# Altium schematic sheet EFE.SchDoc(EFE)
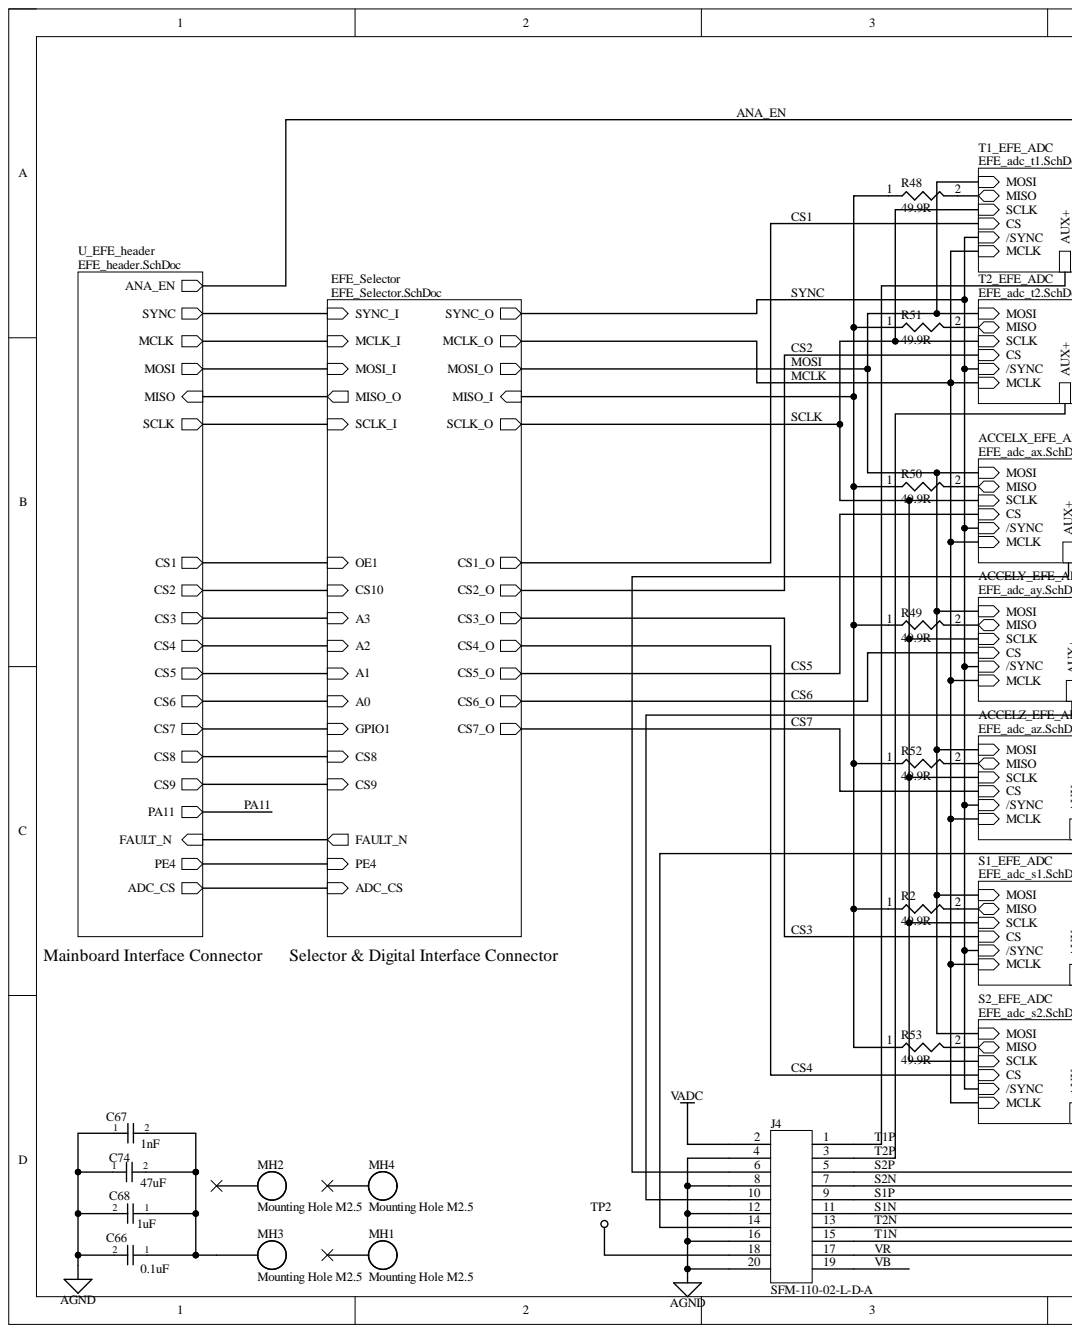
[diagram p0: EFE.SchDoc, left]
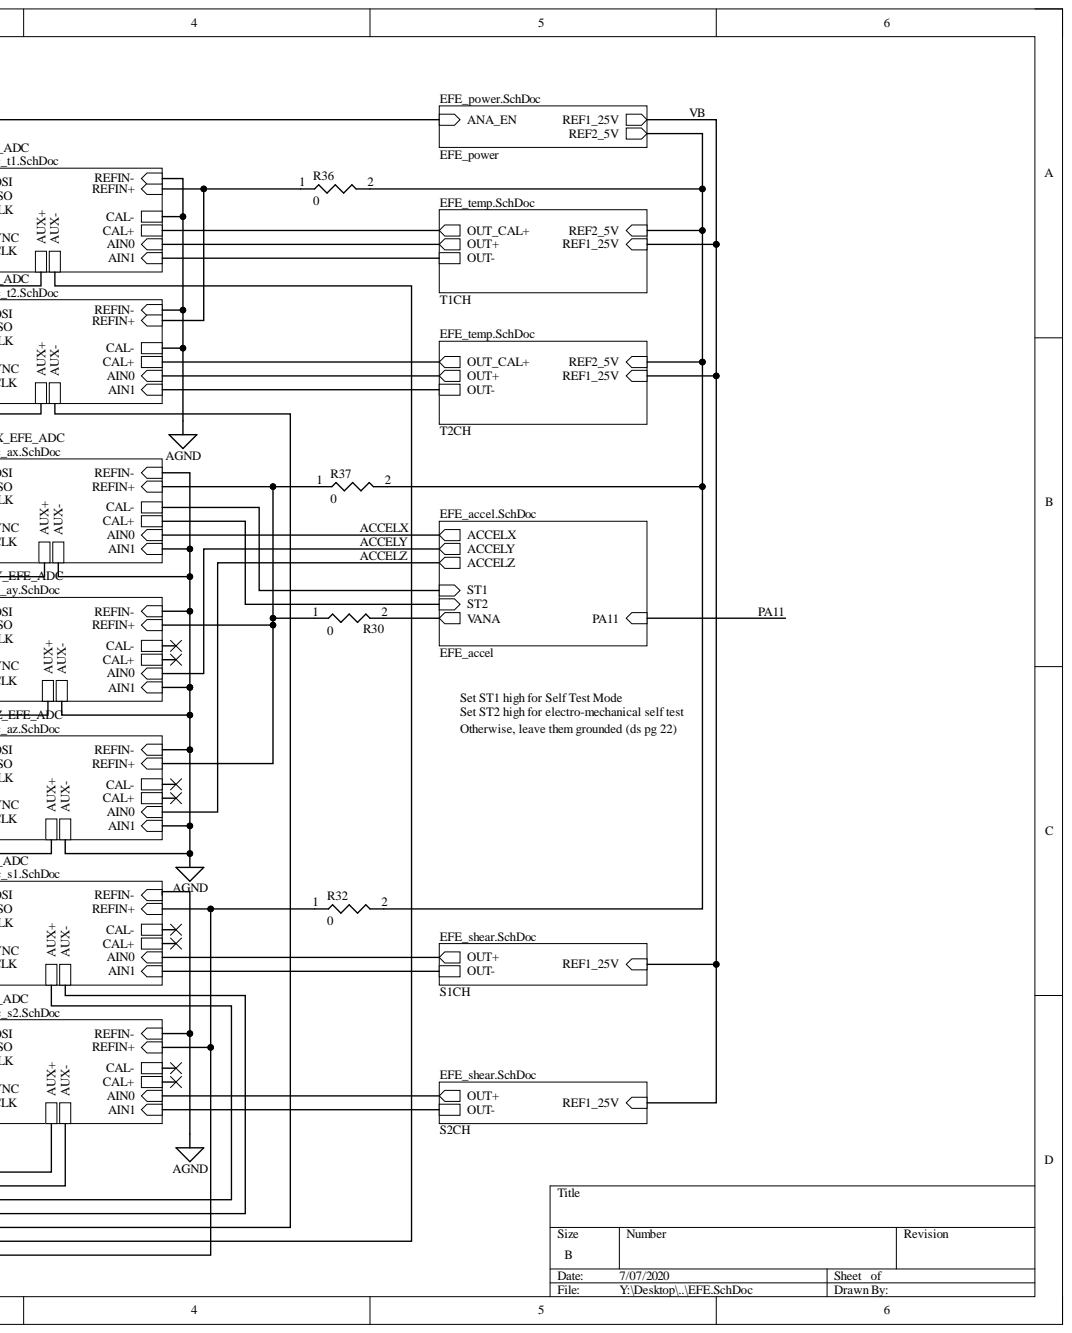
[diagram p1: EFE.SchDoc, right]

## Parts
C66  value 0.1uF  [2012, Concave]  (hidden comment: CC0805KRX7R9BB104)
C67  Capacitance: 1nF  [2012, Concave]  (hidden comment: C0805C102K5RACTU)
C68  Capacitance: 1uF  [2012, Concave]  (hidden comment: CL21B105KBFNNNG)
C74  Capacitance: 47uF  [1210 - Non-Polar]  (hidden comment: TMK325ABJ476MM-P)
J4  SFM-110-02-L-D-A  [SFM-110-02-L-D-A]
MH1  Mounting Hole M2.5  [MOUNTING_HOLE M2.5]
MH2  Mounting Hole M2.5  [MOUNTING_HOLE M2.5]
MH3  Mounting Hole M2.5  [MOUNTING_HOLE M2.5]
MH4  Mounting Hole M2.5  [MOUNTING_HOLE M2.5]
R2  Resistance: 49.9R  [0805]  (hidden comment: ERA-6AEB49R9V)
R30  value 0  [0805]  (hidden comment: RC0805JR-070RL)
R32  value 0  [0805]  (hidden comment: RC0805JR-070RL)
R36  value 0  [0805]  (hidden comment: RC0805JR-070RL)
R37  value 0  [0805]  (hidden comment: RC0805JR-070RL)
R48  Resistance: 49.9R  [0805]  (hidden comment: ERA-6AEB49R9V)
R49  Resistance: 49.9R  [0805]  (hidden comment: ERA-6AEB49R9V)
R50  Resistance: 49.9R  [0805]  (hidden comment: ERA-6AEB49R9V)
R51  Resistance: 49.9R  [0805]  (hidden comment: ERA-6AEB49R9V)
R52  Resistance: 49.9R  [0805]  (hidden comment: ERA-6AEB49R9V)
R53  Resistance: 49.9R  [0805]  (hidden comment: ERA-6AEB49R9V)
TP2  Test-Pad 0805  [TestPad - 0805]  (value not drawn)

## Nets
AGND: C66.2 C67.1 C68.2 C74.1 J4.4 J4.8 J4.12 J4.16 J4.20
VADC: J4.2
S1N: J4.11
S1P: J4.9
S2N: J4.7
S2P: J4.5
T1N: J4.15
T1P: J4.1
T2N: J4.13
T2P: J4.3
VB: J4.19
VR: J4.17 R32.1
NetC66_1: C66.1 C67.2 C68.1 C74.2 MH3.1
NetJ4_6: J4.6
NetJ4_10: J4.10
NetJ4_14: J4.14
NetJ4_18: J4.18 TP2.1
NetMH1_1: MH1.1
NetMH2_1: MH2.1
NetMH4_1: MH4.1
NetR2_1: R2.1 R48.1 R49.1 R50.1 R51.1 R52.1 R53.1
NetR2_2: R2.2
NetR30_1: R30.1 R37.1
NetR30_2: R30.2
NetR32_2: R32.2 R36.2 R37.2
NetR36_1: R36.1
NetR48_2: R48.2
NetR49_2: R49.2
NetR50_2: R50.2
NetR51_2: R51.2
NetR52_2: R52.2
NetR53_2: R53.2
ACCELX:
ACCELY:
ACCELZ:
ANA_EN:
CS1:
CS2:
CS3:
CS4:
CS5:
CS6:
CS7:
MCLK:
MOSI:
N00001:
N00002:
N00003:
N00004:
N00005:
N00006:
N00007:
N00008:
N00009:
N00010:
N00011:
N00012:
N00013:
N00014:
N00015:
N00016:
N00017:
N00018:
N00019:
N00020:
N00021:
N00022:
N00023:
N00024:
N00025:
N00026:
N00027:
N00028:
N00029:
N00030:
N00031:
N00032:
N00033:
N00034:
N00035:
N00036:
N00037:
PA11:
SCLK:
SYNC:

## Library data of the parts
C66 = CC0805KRX7R9BB104: Capacitor - Ceramic, 0.1uF, 50V 0805; Manufacturer: Yageo; Capacitance: 100nF; Dielectric: X7R; Insulation Resistance: 100GR; Tolerance: 10%; Case Code (Imperial): 0805; Case Code (Metric): 2012; Case/Package: 0805; Component Kind: Standard; ComponentLink1Description: http://www.yageo.com/documents/recent/UPY-GPHC_X7R_6.3V-to-50V_8.pdf; ComponentLink1URL: http://www.yageo.com/documents/recent/UPY-GPHC_X7R_6.3V-to-50V_8.pdf; ComponentLink2Description: http://www.farnell.com/datasheets/1489800.pdf; ComponentLink2URL: http://www.farnell.com/datasheets/1489800.pdf; ComponentLink3Description: http://www.yageo.com/documents/recent/UPY-GPHC_X7R_6.3V-to-50V_18.pdf; ComponentLink3URL: http://www.yageo.com/documents/recent/UPY-GPHC_X7R_6.3V-to-50V_18.pdf; ComponentLink4Description: http://www.farnell.com/datasheets/1734573.pdf; ComponentLink4URL: http://www.farnell.com/datasheets/1734573.pdf; ComponentLink5Description: http://www.produktinfo.conrad.com/datenblaetter/425000-449999/445210-da-01-en-KERKO_CHIP_0805_X7R_0_1uF_10Proz_50VG.pdf; ComponentLink5URL: http://www.produktinfo.conrad.com/datenblaetter/425000-449999/445210-da-01-en-KERKO_CHIP_0805_X7R_0_1uF_10Proz_50VG.pdf; Depth: 1.25mm; Halogen Free: Halogen Free; Height: 0.85mm; Lead Free: Lead Free; Length: 2mm; Manufacturer Part Number: CC0805KRX7R9BB104; Material: Ceramic; Max Operating Temperature: 125°C; Min Operating Temperature: -55°C; Mount: Surface Mount; Number of Pins: 2; Package Quantity: 1; Packaging: Tape and Reel; Pricing: 1 = 0.16000, 10 = 0.11300, 100 = 0.05290, 500 = 0.03712, 1000 = 0.03073 (USD); Radiation Hardening: No; REACH SVHC: No SVHC; RoHS: Yes; Stock: 524292; Supplier 1: Digi-Key; Supplier Part Number 1: 311-1140-1-ND; Termination: SMD/SMT; Thickness: 0.033inch; Voltage: 50V; Voltage Rating: 50V; Width: 0.049inch; Library Name: Epsilometer.SCHLIB
C67 = C0805C102K5RACTU: Capacitor - Ceramic, 1000pF, 50V, 0805; Manufacturer: TDK-Lambda; Dielectric: X7R; Tolerance: 10%; Case/Package: 0805; Component Kind: Standard; ComponentLink1Description: http://www.onlinecomponents.com/productFiles/mf-KEM/kem_c1002_x7r_smd.pdf; ComponentLink1URL: http://www.onlinecomponents.com/productFiles/mf-KEM/kem_c1002_x7r_smd.pdf; Dielectric Material: Ceramic; Height: 780um; Length: 2mm; Manufacturer Part Number: C0805C102K5RACTU; Number of Pins: 2; Packaging: Cut Tape; Pricing: 1 = 0.18000, 10 = 0.13000, 100 = 0.06130, 500 = 0.04296, 1000 = 0.03557 (USD); REACH SVHC: No SVHC; Stock: 1159370; Supplier 1: Digi-Key; Supplier Part Number 1: 399-1147-1-ND; Voltage Rating (DC): 50V; Width: 1.25mm
C68 = CL21B105KBFNNNG: Capacitor - Ceramic, 1uF, 50V, 0805; Manufacturer: Samsung; Dielectric: X7R; Tolerance: 10%; Case Code (Imperial): 0805; Case Code (Metric): 2012; Case/Package: 0805; Component Kind: Standard; ComponentLink1Description: http://www1.futureelectronics.com/doc/SAMSUNG%20PASSIVE/CL05B104KQ5NNNC.pdf; ComponentLink1URL: http://www1.futureelectronics.com/doc/SAMSUNG%20PASSIVE/CL05B104KQ5NNNC.pdf; ComponentLink2Description: https://www.distrelec.biz/Web/Downloads/_e/ng/MLCC_CatalogDownload2013_ENG.pdf?mime=application%2Fpdf; ComponentLink2URL: https://www.distrelec.biz/Web/Downloads/_e/ng/MLCC_CatalogDownload2013_ENG.pdf?mime=application%2Fpdf; ComponentLink3Description: http://www.samsungsem.com/kr/support/product-search/mlcc/CL21B105KBFNNNG.jsp; ComponentLink3URL: http://www.samsungsem.com/kr/support/product-search/mlcc/CL21B105KBFNNNG.jsp; ComponentLink4Description: https://produktinfo.conrad.com/datenblaetter/450000-474999/455898-da-01-en-VS_KONDENSATOR_0805.pdf; ComponentLink4URL: https://produktinfo.conrad.com/datenblaetter/450000-474999/455898-da-01-en-VS_KONDENSATOR_0805.pdf; Contact Plating: Tin; Depth: 1.25mm; Length: 2mm; Manufacturer Part Number: CL21B105KBFNNNG; Material: Ceramic; Max Operating Temperature: 125°C; Min Operating Temperature: -55°C; Mount: Surface Mount; Package Quantity: 3000; Packaging: Tape and Reel; Pricing: 1 = 0.14000, 10 = 0.10000, 100 = 0.04470, 500 = 0.03194, 1000 = 0.02509 (USD); RoHS: Yes; Stock: 556410; Supplier 1: Digi-Key; Supplier Part Number 1: 1276-6470-1-ND; Termination: Wraparound; Thickness: 0.053inch; Voltage: 50V; Voltage Rating: 50V; Width: 0.049inch; Library Name: Epsilometer.SCHLIB
C74 = TMK325ABJ476MM-P: Capacitor - Ceramic, 47uF, 25V, 1210; Manufacturer: Taiyo Yuden; Dielectric: X5R; Tolerance: 20%; Case/Package: Ceramic; Component Kind: Standard; ComponentLink1Description: http://ds.yuden.co.jp/TYCOMPAS/ut/detail.do?productNo=TMK325ABJ476MM-P&fileName=TMK325ABJ476MM-P_SS&mode=specSheetDownload&src-supplier=Digi-Key; ComponentLink1URL: http://ds.yuden.co.jp/TYCOMPAS/ut/detail.do?productNo=TMK325ABJ476MM-P&fileName=TMK325ABJ476MM-P_SS&mode=specSheetDownload&src-supplier=Digi-Key; ComponentLink2Description: http://www.yuden.co.jp/productdata/catalog/en/mlcc_all_e.pdf?src-supplier=Avnet+Asia; ComponentLink2URL: http://www.yuden.co.jp/productdata/catalog/en/mlcc_all_e.pdf?src-supplier=Avnet+Asia; ComponentLink3Description: https://ds.yuden.co.jp/TYCOMPAS/ut/detail?pn=TMK325ABJ476MM-P &amp;u=M&src-supplier=Digi-Key; ComponentLink3URL: https://ds.yuden.co.jp/TYCOMPAS/ut/detail?pn=TMK325ABJ476MM-P &amp;u=M&src-supplier=Digi-Key; Contact Plating: Tin; Lead Free: Lead Free; Length: 3.2mm; Manufacturer Part Number: TMK325ABJ476MM-P; Max Operating Temperature: 85°C; Min Operating Temperature: -55°C; Mount: Surface Mount; Packaging: Tape and Reel; Pricing: 1=1.35, 10=1.066, 100=0.7998, 500=0.6043 (USD); RoHS: Yes; Stock: 4; Supplier 1: Digi-Key; Supplier Part Number 1: 587-5479-1-ND; Termination: Wraparound; Library Name: Epsilometer.SCHLIB
J4 = SFM-110-02-L-D-A: 20-position Receptacle Surface Mount Connector; Manufacturer: Samtec; Connector Type: Receptacle,Socket; Contact Resistance: 15mR; Max Voltage Rating (AC): 250V; Number of Contacts: 20; Pitch: 1.27mm; Row Spacing: 0.05inch; Color: Black; Component Kind: Standard; ComponentLink1Description: https://datasheet.ciiva.com/756/sfm-1xx-xx-xxx-d-xxx-mkt-756126.pdf?src-supplier=Digi-Key; ComponentLink1URL: https://datasheet.ciiva.com/756/sfm-1xx-xx-xxx-d-xxx-mkt-756126.pdf?src-supplier=Digi-Key; Contact Material: Copper; Contact Plating: Gold; Depth: 3.68mm; Gender: Receptacle; Housing Color: Black; Housing Material: Polymer; Length: 15.87mm; Manufacturer Part Number: SFM-110-02-L-D-A; Max Operating Temperature: 125°C; Min Operating Temperature: -55°C; Mount: Surface Mount; Number of Positions: 20; Number of Rows: 2; Orientation: Straight; Packaging: Rail/Tube; Pricing: 1=3.2, 10=3.2, 25=3.2, 50=2.96, 100=2.96, 250=2.96, 500=2.96 (USD); Radiation Hardening: No; REACH SVHC: No SVHC; RoHS: Yes; Stock: 70; Supplier 1: Newark; Supplier Part Number 1: 97P5428; System: Connector; Termination: Solder; Library Name: Epsilometer.SCHLIB
R2 R48-R53 = ERA-6AEB49R9V: Resistor - 49.9ohm, 0805; Manufacturer: Panasonic; Max Power Dissipation: 125mW; Temperature Coefficient: 25ppm/°C; Tolerance: 0.1%; Voltage Rating: 100V; Case Code (Imperial): 0805; Case Code (Metric): 2012; Case/Package: 0805; Component Kind: Standard; ComponentLink1Description: http://www.farnell.com/datasheets/485442.pdf?src-supplier=Farnell; ComponentLink1URL: http://www.farnell.com/datasheets/485442.pdf?src-supplier=Farnell; ComponentLink2Description: http://industrial.panasonic.com/www-data/pdf/AOA0000/AOA0000CE26.pdf?src-supplier=Future+Electronics; ComponentLink2URL: http://industrial.panasonic.com/www-data/pdf/AOA0000/AOA0000CE26.pdf?src-supplier=Future+Electronics; ComponentLink3Description: http://www.farnell.com/datasheets/1776116.pdf?src-supplier=Element14; ComponentLink3URL: http://www.farnell.com/datasheets/1776116.pdf?src-supplier=Element14; ComponentLink4Description: http://industrial.panasonic.com/www-data/pdf/AOA0000/AOA0000CE26.pdf?src-supplier=Avnet+Europe; ComponentLink4URL: http://industrial.panasonic.com/www-data/pdf/AOA0000/AOA0000CE26.pdf?src-supplier=Avnet+Europe; ComponentLink5Description: http://uk.rs-online.com/webdocs/0eb1/0900766b80eb1d8c.pdf?src-supplier=RSComponents; ComponentLink5URL: http://uk.rs-online.com/webdocs/0eb1/0900766b80eb1d8c.pdf?src-supplier=RSComponents; ComponentLink6Description: http://www.farnell.com/datasheets/485442.pdf?src-supplier=Element14; ComponentLink6URL: http://www.farnell.com/datasheets/485442.pdf?src-supplier=Element14; ComponentLink7Description: https://www.alliedelec.com/m/d/b6b0537e86f421aa7f5975bb1d8f429a.pdf?src-supplier=Allied+Electronics; ComponentLink7URL: https://www.alliedelec.com/m/d/b6b0537e86f421aa7f5975bb1d8f429a.pdf?src-supplier=Allied+Electronics; ComponentLink8Description: https://produktinfo.conrad.com/datenblaetter/450000-474999/459241-da-01-en-WIDERSTAND_0805_ERA.pdf?src-supplier=Conrad; ComponentLink8URL: https://produktinfo.conrad.com/datenblaetter/450000-474999/459241-da-01-en-WIDERSTAND_0805_ERA.pdf?src-supplier=Conrad; Composition: Thin Film,Metal Film; Contact Plating: Tin; Depth: 1.25mm; Features: Automotive AEC-Q200; Height: 0.5mm; Lead Free: Lead Free; Length: 2mm; Manufacturer Part Number: ERA-6AEB49R9V; Max Operating Temperature: 155°C; Military Standard: Not; Min Operating Temperature: -55°C; Mount: Surface Mount; Number of Pins: 2; Number of Terminations: 2; Package Quantity: 1; Packaging: Tape and Reel; Power Rating: 125mW; Pricing: 5000=0.03749, 10000=0.03499, 25000=0.03451 (USD); Radiation Hardening: No; REACH SVHC: Unknown; Stock: 80000; Supplier 1: Digi-Key; Supplier Part Number 1: P49.9DATR-ND; Termination: SMD/SMT; Voltage Rating (DC): 100V; Weight: 0.000194oz; Width: 1.25mm; Library Name: Epsilometer.SCHLIB
R30 R32 R36 R37 = RC0805JR-070RL: Resistor - 0Ω, 0.125W, 0805; Manufacturer: Yageo; Power Rating: 125mW; Resistance: 0mR; Temperature Coefficient: 200ppm/°C; Tolerance: 5%; Voltage Rating: 150V; Case Code (Imperial): 0805; Case Code (Metric): 2012; Case/Package: 0805; Component Kind: Standard; ComponentLink1Description: http://www.farnell.com/datasheets/1738694.pdf; ComponentLink1URL: http://www.farnell.com/datasheets/1738694.pdf; ComponentLink2Description: http://documents.rs-components.com/techlib://itc_doclist_GB.htm?stockno=6185129; ComponentLink2URL: http://documents.rs-components.com/techlib://itc_doclist_GB.htm?stockno=6185129; ComponentLink3Description: https://www.verical.com/server-webapp/html/getDatasheet?partID=330685; ComponentLink3URL: https://www.verical.com/server-webapp/html/getDatasheet?partID=330685; Composition: Thick Film; Contact Plating: Matte,Tin; Depth: 1.25mm; Height: 0.5mm; Lead Free: Lead Free; Length: 2mm; Manufacturer Part Number: RC0805JR-070RL; Max Operating Temperature: 155°C; Military Standard: Not; Min Operating Temperature: -55°C; Number of Pins: 2; Packaging: Tape and Reel; Pricing: 1 = 0.10000, 10 = 0.03700, 25 = 0.02720, 50 = 0.02060, 100 = 0.01530, 250 = 0.01164, 500 = 0.00930, 1000 = 0.00685 (USD); Radiation Hardening: No; REACH SVHC: Unknown; RoHS: Yes; Stock: 18149900; Supplier 1: Digi-Key; Supplier Part Number 1: 311-0.0ARCT-ND; Termination: SMD/SMT; Voltage Rating (DC): 150V; Width: 1.25mm
TP2 = Test-Pad 0805: TestPad - Single 0805 pad size; Component Kind: Standard; Library Name: Epsilometer.SCHLIB
MH1-MH4 = Mounting Hole M2.5: Component Kind: Standard; Library Name: Epsilometer.SCHLIB
